# Altium schematic sheet GNSS.SchDoc
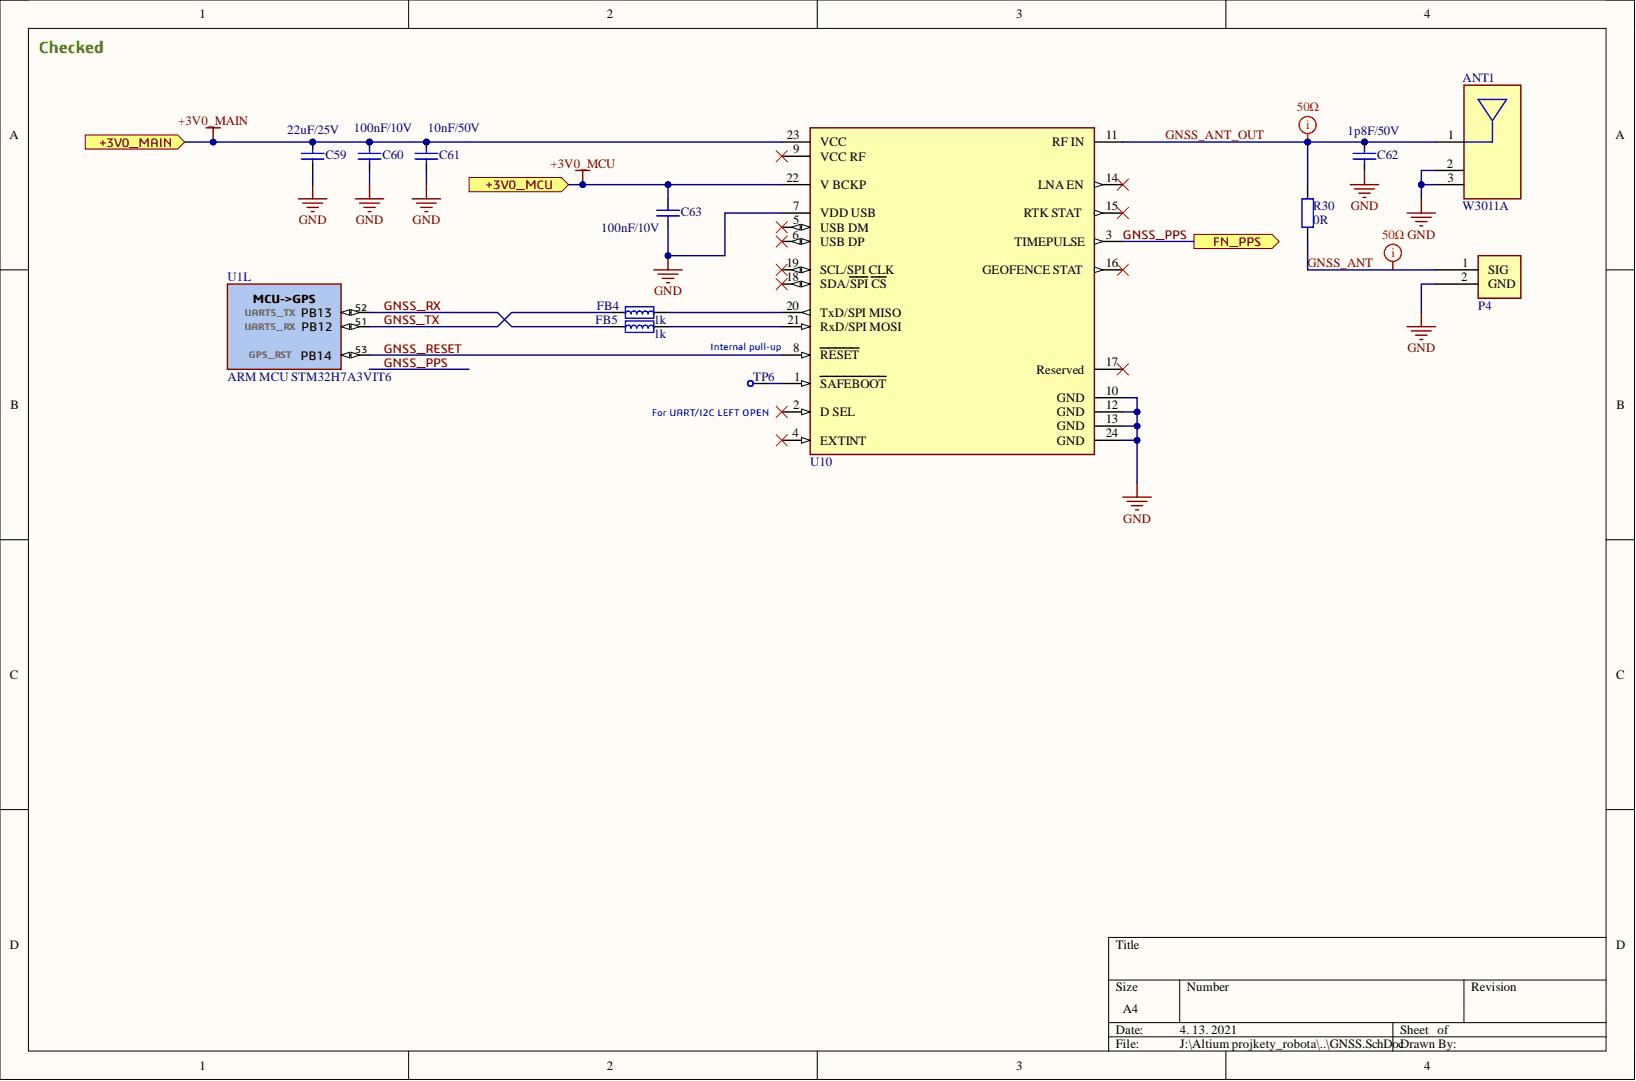
[diagram p0: GNSS.SchDoc]

## Parts
ANT1  W3011A  [W3011A]
C59  22uF/25V  [CAPACITOR_0805_(2012)]
C60  100nF/10V  [CAPACITOR_0402_(1005)]
C61  10nF/50V  [CAPACITOR_0402_(1005)]
C62  1p8F/50V  [CAPACITOR_0402_(1005)]
C63  100nF/10V  [CAPACITOR_0402_(1005)]
FB4  1k  [FERRITE BEAD_0402_(1005)]
FB5  1k  [FERRITE BEAD_0402_(1005)]
P4  U.FL-R-SMT-1(10)  [U.FL-R-SMT-1]  (value not drawn)  (no BOM)
R30  0R  [RESISTOR_0402_(1005)]  (no BOM)
TP6  test point  [TP]  (value not drawn)  (no BOM)
U1  ARM MCU STM32H7A3VIT6  Manufacturer Part Number: STM32H7A3VIT6  [STM-LQFP100_N - Edited]  (part L)
U10  NEO-M8Q  [NEO-M8Q-0-11-MFG]  (value not drawn)

## Ports
+3V0_MAIN, +3V0_MCU, FN_PPS

## Nets
GND: ANT1.2 ANT1.3 C59.1 C60.2 C61.2 C62.1 C63.2 P4.2 U10.7(VDD_USB) U10.10(GND) U10.12(GND) U10.13(GND) U10.24(GND)
+3V0_MAIN: C59.2 C60.1 C61.1 U10.23(VCC) ; port +3V0_MAIN
+3V0_MCU: C63.1 U10.22(V_BCKP) ; port +3V0_MCU
GNSS_ANT: P4.1 R30.1
GNSS_ANT_OUT: ANT1.1 C62.2 R30.2 U10.11(RF_IN)
GNSS_PPS: U10.3(TIMEPULSE) ; port FN_PPS
GNSS_RESET: U1.53(PB14) U10.8(RESET)
GNSS_RX: FB5.1 U1.52(PB13)
GNSS_TX: FB4.1 U1.51(PB12)
NetFB4_2: FB4.2 U10.20(TxD/SPI_MISO)
NetFB5_2: FB5.2 U10.21(RxD/SPI_MOSI)
NetTP6_1: TP6.1 U10.1(SAFEBOOT)

Not connected: U10 2(D_SEL) 4(EXTINT) 5(USB_DM) 6(USB_DP) 9(VCC_RF) 14(LNA_EN) 15(RTK_STAT) 16(GEOFENCE_STAT) 17(Reserved) 18(SDA/SPI_CS) 19(SCL/SPI_CLK)

## Library data of the parts
ANT1 = Antenna GPS Chip: ANTENNA GPS CERAMIC 1.575GHZ; Manufacturer: Pulse; Impedance: 50R; Number of Bands: 1; Return Loss: -16dB; Case/Package: CSMD; Category: RF Antennas; Component Kind: Standard; ComponentLink1Description: https://datasheet.ciiva.com/4432/13567-4432626.pdf?src-supplier=Mouser; ComponentLink1URL: https://datasheet.ciiva.com/4432/13567-4432626.pdf?src-supplier=Mouser; ComponentLink2Description: https://datasheet.ciiva.com/8153/+w3011-w3011a-8153001.pdf?src-supplier=Avnet; ComponentLink2URL: https://datasheet.ciiva.com/8153/+w3011-w3011a-8153001.pdf?src-supplier=Avnet; ComponentLink3Description: https://datasheet.ciiva.com/2791/+w3011-w3011a-2791948.pdf?src-supplier=Digi-Key; ComponentLink3URL: https://datasheet.ciiva.com/2791/+w3011-w3011a-2791948.pdf?src-supplier=Digi-Key; ComponentLink4Description: https://datasheet.ciiva.com/2791/+w3011-w3011a-2791949.pdf?src-supplier=Digi-Key; ComponentLink4URL: https://datasheet.ciiva.com/2791/+w3011-w3011a-2791949.pdf?src-supplier=Digi-Key; ComponentLink5Description: https://datasheet.ciiva.com/2791/+w3011-w3011a-2791950.pdf?src-supplier=Digi-Key; ComponentLink5URL: https://datasheet.ciiva.com/2791/+w3011-w3011a-2791950.pdf?src-supplier=Digi-Key; Frequency: 1.575GHz; Height: 0.043inch; Length: 3.2mm; Library Name: BB1_SCH_Library.SchLib; Manufacturer Part Number: W3011A; Max Operating Temperature: 85°C; Min Operating Temperature: -40°C; Mount: Surface Mount; Number of Pins: 2; Package Quantity: 1; Packaging: Bulk; Pricing 1: 1=1,58000, 10=1,33000, 100=1,14000, 250=1,07000, 500=0,93700, 1000=0,75400, 3000=0,70200, 6000=0,67600, 9000=0,65000 (USD); RoHS: Yes; Stock 1: 2838; Supplier 1: Mouser; Supplier Part Number 1: 673-W3011A; Termination: Solder; Width: 1.6mm
C59 = CAPACITOR: Manufacturer: Murata; Manufacturer Part Number 1: GRM21BR61E226ME44L; Dielectric: X5R; Material: Ceramic; Tolerance: 20%; Voltage: 25V; Capacitance: 22uF/25V; Case Code (Imperial): 0805; Case Code (Metric): 2012; Case/Package: 0805; Component Kind: Standard; ComponentLink1Description: https://datasheet.ciiva.com/22787/1747471-22787265.pdf?src-supplier=Farnell; ComponentLink1URL: https://datasheet.ciiva.com/22787/1747471-22787265.pdf?src-supplier=Farnell; ComponentLink2Description: https://datasheet.ciiva.com/7719/1747471-7719095.pdf?src-supplier=Element14; ComponentLink2URL: https://datasheet.ciiva.com/7719/1747471-7719095.pdf?src-supplier=Element14; ComponentLink3Description: https://datasheet.ciiva.com/28584/1747471-28584199.pdf?src-supplier=Element14; ComponentLink3URL: https://datasheet.ciiva.com/28584/1747471-28584199.pdf?src-supplier=Element14; Composition: Ceramic; Depth: 1.25mm; Description: CAPACITOR; Height: 1.25mm; Lead Free: Lead Free; Length: 2mm; Max Operating Temperature: 85°C; Min Operating Temperature: -55°C; Mount: Surface Mount; Package Quantity: 3000; Packaging: Tape and Reel; Pricing 1: 5=0,42800, 50=0,25100, 250=0,20300, 500=0,15000, 1500=0,12800 (EUR); REACH SVHC: No SVHC; RoHS: Yes; Stock 1: 70400; Supplier 1: Farnell; Supplier Part Number 1: 1907510; Termination: SMD/SMT; Thickness: 0.057inch; Voltage Rating: 25V; Voltage Rating (DC): 25V; Weight: 0.000335oz; Width: 1.25mm; Library Name: RLCFB.SchLib
C60 C63 = CAPACITOR: ESR: 0.28R; Voltage Rating: 10V; Capacitance: 100nF/10V; Component Kind: Standard; Description: CAPACITOR; Library Name: RLCFB.SchLib
C61 = CAPACITOR: ESR: Low ESR; Voltage Rating: 50V; Capacitance: 10nF/50V; Component Kind: Standard; Description: CAPACITOR; Library Name: RLCFB.SchLib
C62 = CAPACITOR: ESR: 0.28R; Voltage Rating: 50V; Capacitance: 1p8F/50V; Component Kind: Standard; Description: CAPACITOR; Library Name: RLCFB.SchLib
FB4 FB5 = FERRITE_BEAD: Manufacturer: Murata; Manufacturer Part Number 1: BLM15HD102SN1D; Current Rating: 250mA; DC Resistance (DCR): 1.25R; Frequency: 100MHz; Impedance: 1kR; Material: Steel,Ferrite; Number of Circuits: 1; Shielding: Unshielded; Tolerance: 25%; Case Code (Imperial): 0402; Case Code (Metric): 1005; Case/Package: 0402; Component Kind: Standard; ComponentLink1Description: https://datasheet.ciiva.com/11799/0900766b811b5866-11799124.pdf?src-supplier=RSComponents; ComponentLink1URL: https://datasheet.ciiva.com/11799/0900766b811b5866-11799124.pdf?src-supplier=RSComponents; ComponentLink2Description: https://datasheet.ciiva.com/18089/getdatasheetpartid-153027-18089625.pdf?src-supplier=Verical; ComponentLink2URL: https://datasheet.ciiva.com/18089/getdatasheetpartid-153027-18089625.pdf?src-supplier=Verical; ComponentLink3Description: https://datasheet.ciiva.com/27054/1844707-27054220.pdf?src-supplier=Jameco; ComponentLink3URL: https://datasheet.ciiva.com/27054/1844707-27054220.pdf?src-supplier=Jameco; ComponentLink4Description: https://datasheet.ciiva.com/41449/001172200-da-01-en-drossel-0402-1000r-21-0x0-5mm-10000st-41449488.pdf?src-supplier=Conrad; ComponentLink4URL: https://datasheet.ciiva.com/41449/001172200-da-01-en-drossel-0402-1000r-21-0x0-5mm-10000st-41449488.pdf?src-supplier=Conrad; ComponentLink5Description: https://datasheet.ciiva.com/41425/001171683-da-01-en-chip-drossel0402-1000r-250ma-1-0x0-5mm-41425767.pdf?src-supplier=Conrad; ComponentLink5URL: https://datasheet.ciiva.com/41425/001171683-da-01-en-chip-drossel0402-1000r-250ma-1-0x0-5mm-41425767.pdf?src-supplier=Conrad; ComponentLink6Description: https://datasheet.ciiva.com/3081/l0110s0101blm15h-3081604.pdf?src-supplier=Avnet; ComponentLink6URL: https://datasheet.ciiva.com/3081/l0110s0101blm15h-3081604.pdf?src-supplier=Avnet; ComponentLink7Description: https://datasheet.ciiva.com/3081/l0110s0101blm15h-3081605.pdf?src-supplier=Avnet; ComponentLink7URL: https://datasheet.ciiva.com/3081/l0110s0101blm15h-3081605.pdf?src-supplier=Avnet; ComponentLink8Description: https://datasheet.ciiva.com/1012/l0110s0101blm15h-1012794.pdf?src-supplier=Digi-Key; ComponentLink8URL: https://datasheet.ciiva.com/1012/l0110s0101blm15h-1012794.pdf?src-supplier=Digi-Key; ComponentLink9Description: https://datasheet.ciiva.com/16373/l0110s0101blm15h-16373866.pdf?src-supplier=Future+Electronics; ComponentLink9URL: https://datasheet.ciiva.com/16373/l0110s0101blm15h-16373866.pdf?src-supplier=Future+Electronics; ComponentLink10Description: https://datasheet.ciiva.com/1012/l0110s0101blm15h-1012795.pdf?src-supplier=Digi-Key; ComponentLink10URL: https://datasheet.ciiva.com/1012/l0110s0101blm15h-1012795.pdf?src-supplier=Digi-Key; ComponentLink11Description: https://datasheet.ciiva.com/1466/5168-1466859.pdf?src-supplier=Element14; ComponentLink11URL: https://datasheet.ciiva.com/1466/5168-1466859.pdf?src-supplier=Element14; ComponentLink12Description: https://datasheet.ciiva.com/1012/l0110s0101blm15h-1012796.pdf?src-supplier=Digi-Key; ComponentLink12URL: https://datasheet.ciiva.com/1012/l0110s0101blm15h-1012796.pdf?src-supplier=Digi-Key; ComponentLink13Description: https://datasheet.ciiva.com/1466/5168-1466860.pdf?src-supplier=Element14; ComponentLink13URL: https://datasheet.ciiva.com/1466/5168-1466860.pdf?src-supplier=Element14; Contact Plating: Tin,Matte; Core Material: Ferrite; DC Current: 250mA; Depth: 0.5mm; Description: FERRITE_BEAD; Height: 0.5mm; Impedance@100MHz: 1k; Lead Free: Lead Free; Length: 1mm; Max Current Rating: 250mA; Max DC Current: 250mA; Max Operating Temperature: 125°C; Military Standard: Not; Min Operating Temperature: -55°C; Number of Lines: 1; Number of Pins: 2; Package Quantity: 1; Packaging: Tape and Reel; Pricing 1: 10=0,06380, 100=0,03950, 500=0,02860, 2500=0,02130, 5000=0,01890, 10000=0,01690, 50000=0,01480 (EUR); Radiation Hardening: No; REACH SVHC: No SVHC; Resistance: 1.25R; RoHS: Yes; Stock 1: 51262; Supplier 1: Farnell; Supplier Part Number 1: 1515785; Termination: SMD/SMT; Test Frequency: 100MHz; Width: 0.5mm; Library Name: RLCFB.SchLib
P4 = U.FL connector: HIROSE(HRS) - U.FL-R-SMT-1(10) - RF COAXIAL, U.FL, STRAIGHT JACK, 50OHM; Body Material: Bronze; Body Plating: Silver; Connector Type: Coaxial,Receptacle,Male Pin; Dielectric Material: Polymer; Frequency: 6GHz; Gender: Male; Impedance: 50R; Insulation Resistance: 500MR; Category: RF / Coaxial Connectors; ComponentLink1Description: https://datasheet.ciiva.com/11762/0900766b8105243f-11762474.pdf?src-supplier=RSComponents; ComponentLink1URL: https://datasheet.ciiva.com/11762/0900766b8105243f-11762474.pdf?src-supplier=RSComponents; ComponentLink2Description: https://datasheet.ciiva.com/7651/91524-7651914.pdf?src-supplier=Element14; ComponentLink2URL: https://datasheet.ciiva.com/7651/91524-7651914.pdf?src-supplier=Element14; ComponentLink3Description: https://datasheet.ciiva.com/7651/91524-7651915.pdf?src-supplier=Element14; ComponentLink3URL: https://datasheet.ciiva.com/7651/91524-7651915.pdf?src-supplier=Element14; Contact Material: Brass,Bronze; Contact Plating: Gold; Depth: 3.1mm; Fastening Type: Snap; Housing Color: Beige; Lead Free: Lead Free; Length: 3mm; Library Name: BB1_SCH_Library.SchLib; Manufacturer: Hirose; Manufacturer Part Number: U.FL-R-SMT-1(10); Max Frequency: 6GHz; Max Operating Temperature: 90°C; Min Operating Temperature: -40°C; Mount: Surface Mount,Through Hole,PCB; Orientation: Straight; Package Quantity: 2500; Packaging: Tape and Reel; Pricing 1: 1=1,220000, 10=0,965000, 100=0,924000, 250=0,840000, 500=0,771000, 1000=0,610000, 2500=0,600000 (USD); Radiation Hardening: No; REACH SVHC: No SVHC; RoHS: Yes; Stock 1: 195671; Supplier 1: Mouser; Supplier Part Number 1: 798-U.FL-R-SMT-110; Termination: Solder; Wire/Cable Type: Coaxial
R30 = RESISTOR: Power Rating: 500mW; Description: RESISTOR; Resistance: 0R; Library Name: RLCFB.SchLib
U1 = STM32H7A3VIT6_LQFP100: STM32H7A3VIT6 -  32-bit Arm® Cortex®-M7 280 MHz MCUs, up to 2-Mbyte Flash memory, 1.4Mbyte RAM, 46 com. and analog interfaces; Manufacturer: STMicroelectronics; Category: Microcontrollers; Component Kind: Standard; ComponentLink1Description: Datasheet; ComponentLink1URL: https://www.st.com/resource/en/datasheet/stm32h7a3vi.pdf; Library Name: BB1_SCH_Library.SchLib; RoHS: No; Supplier 1: Mouser; Supplier Part Number 1: 511-STM32H7A3VIT6
U10 = NEO-M8Q: IC RECEIVER GPS/GNSS 24LCC; Manufacturer: u-blox; Manufacturer Part Number: NEO-M8Q-0; Current - Receiving: 21mA; Frequency: 1.575GHz; Interface: I2C,SPI,USB,UART; Sensitivity: -167dBm; Case/Package: SMD/SMT; Category: RF Receivers, Transceivers; Component Kind: Standard; ComponentLink1Description: https://www.u-blox.com/sites/default/files/NEO-M8-FW3_DataSheet_%28UBX-15031086%29.pdf?src-supplier=Digi-Key; ComponentLink1URL: https://www.u-blox.com/sites/default/files/NEO-M8-FW3_DataSheet_%28UBX-15031086%29.pdf?src-supplier=Digi-Key; ComponentLink2Description: https://www.u-blox.com/sites/default/files/products/documents/NEO-M8-FW3_ProductSummary_%28UBX-16000345%29.pdf?src-supplier=Digi-Key; ComponentLink2URL: https://www.u-blox.com/sites/default/files/products/documents/NEO-M8-FW3_ProductSummary_%28UBX-16000345%29.pdf?src-supplier=Digi-Key; Library Name: BB1_SCH_Library.SchLib; Max Operating Temperature: 85°C; Min Operating Temperature: -40°C; Packaging: Cut Tape; Pricing 1: 1=25,00000, 10=20,24400, 50=16,62160, 100=13,31410 (USD); RoHS: No; Stock 1: 6210; Supplier 1: Digi-Key; Supplier Part Number 1: 672-NEO-M8Q-0CT-ND
TP6 = test point: Library Name: BB1_SCH_Library.SchLib
